# Altium schematic sheet Microphone-Arm_V1.0.SchDoc
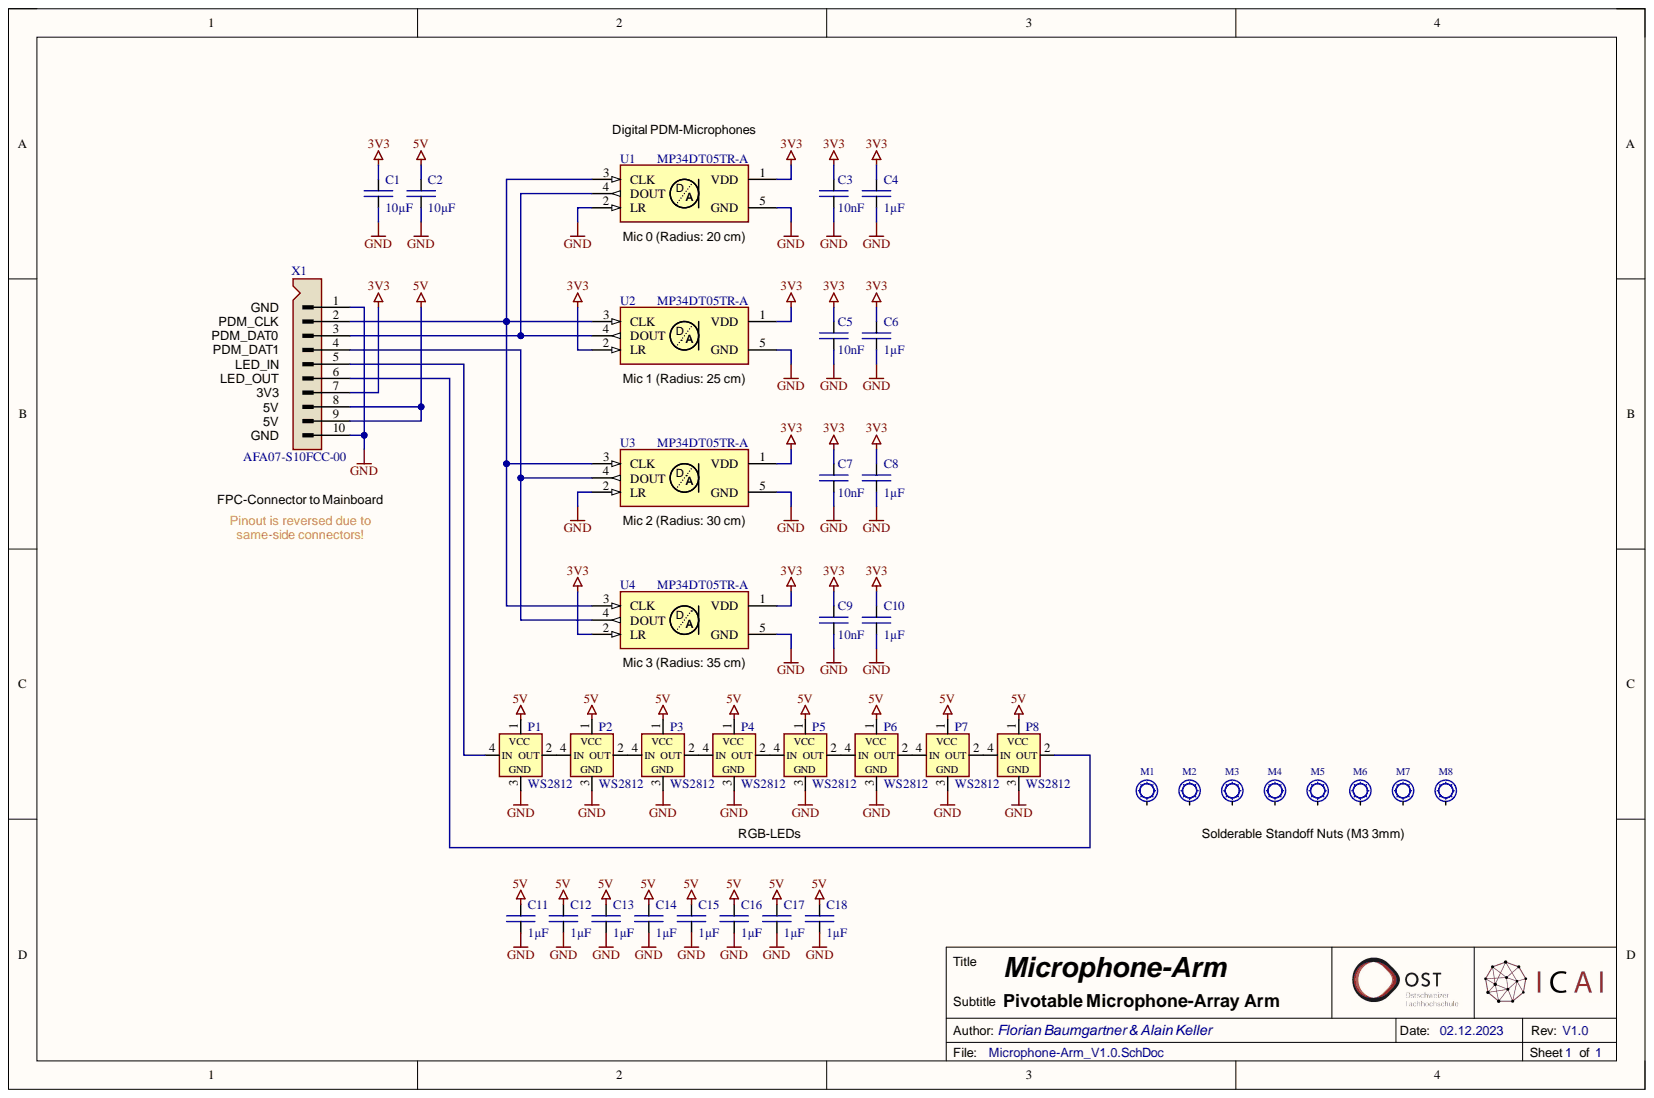
[diagram p0: Microphone-Arm_V1.0.SchDoc]

## Parts
C1  10µF  [SMD Keramikkondensator 1206]
C2  10µF  [SMD Keramikkondensator 1206]
C3  10nF  [SMD Keramikkondensator 0603]
C4  1µF  [SMD Keramikkondensator 0603]
C5  10nF  [SMD Keramikkondensator 0603]
C6  1µF  [SMD Keramikkondensator 0603]
C7  10nF  [SMD Keramikkondensator 0603]
C8  1µF  [SMD Keramikkondensator 0603]
C9  10nF  [SMD Keramikkondensator 0603]
C10  1µF  [SMD Keramikkondensator 0603]
C11  1µF  [SMD Keramikkondensator 0603]
C12  1µF  [SMD Keramikkondensator 0603]
C13  1µF  [SMD Keramikkondensator 0603]
C14  1µF  [SMD Keramikkondensator 0603]
C15  1µF  [SMD Keramikkondensator 0603]
C16  1µF  [SMD Keramikkondensator 0603]
C17  1µF  [SMD Keramikkondensator 0603]
C18  1µF  [SMD Keramikkondensator 0603]
M1  SMTSO-M3-3ET  [SMD Solder-Nut (M3 3mm)]  (value not drawn)
M2  SMTSO-M3-3ET  [SMD Solder-Nut (M3 3mm)]  (value not drawn)
M3  SMTSO-M3-3ET  [SMD Solder-Nut (M3 3mm)]  (value not drawn)
M4  SMTSO-M3-3ET  [SMD Solder-Nut (M3 3mm)]  (value not drawn)
M5  SMTSO-M3-3ET  [SMD Solder-Nut (M3 3mm)]  (value not drawn)
M6  SMTSO-M3-3ET  [SMD Solder-Nut (M3 3mm)]  (value not drawn)
M7  SMTSO-M3-3ET  [SMD Solder-Nut (M3 3mm)]  (value not drawn)
M8  SMTSO-M3-3ET  [SMD Solder-Nut (M3 3mm)]  (value not drawn)
P1  WS2812  [SMD LED WS2812B-0807]
P2  WS2812  [SMD LED WS2812B-0807]
P3  WS2812  [SMD LED WS2812B-0807]
P4  WS2812  [SMD LED WS2812B-0807]
P5  WS2812  [SMD LED WS2812B-0807]
P6  WS2812  [SMD LED WS2812B-0807]
P7  WS2812  [SMD LED WS2812B-0807]
P8  WS2812  [SMD LED WS2812B-0807]
U1  MP34DT05TR-A  [SMD Microphone (MP34DT05TR-A)]
U2  MP34DT05TR-A  [SMD Microphone (MP34DT05TR-A)]
U3  MP34DT05TR-A  [SMD Microphone (MP34DT05TR-A)]
U4  MP34DT05TR-A  [SMD Microphone (MP34DT05TR-A)]
X1  AFA07-S10FCC-00  [SMD Connector (AFA07-S10FCC-00)]

## Nets
3V3: C1.2 C3.2 C4.2 C5.2 C6.2 C7.2 C8.2 C9.2 C10.2 U1.1(VDD) U2.1(VDD) U2.2(LR) U3.1(VDD) U4.1(VDD) U4.2(LR) X1.7
5V: C2.2 C11.2 C12.2 C13.2 C14.2 C15.2 C16.2 C17.2 C18.2 P1.1 P2.1 P3.1 P4.1 P5.1 P6.1 P7.1 P8.1 X1.8 X1.9
GND: C1.1 C2.1 C3.1 C4.1 C5.1 C6.1 C7.1 C8.1 C9.1 C10.1 C11.1 C12.1 C13.1 C14.1 C15.1 C16.1 C17.1 C18.1 P1.3 P2.3 P3.3 P4.3 P5.3 P6.3 P7.3 P8.3 U1.2(LR) U1.5(GND) U2.5(GND) U3.2(LR) U3.5(GND) U4.5(GND) X1.1 X1.10
NetP1_2: P1.2(OUT) P2.4(IN)
NetP1_4: P1.4(IN) X1.5
NetP2_2: P2.2(OUT) P3.4(IN)
NetP3_2: P3.2(OUT) P4.4(IN)
NetP4_2: P4.2(OUT) P5.4(IN)
NetP5_2: P5.2(OUT) P6.4(IN)
NetP6_2: P6.2(OUT) P7.4(IN)
NetP7_2: P7.2(OUT) P8.4(IN)
NetP8_2: P8.2(OUT) X1.6
NetU1_3: U1.3(CLK) U2.3(CLK) U3.3(CLK) U4.3(CLK) X1.2
NetU1_4: U1.4(DOUT) U2.4(DOUT) X1.3
NetU3_4: U3.4(DOUT) U4.4(DOUT) X1.4

Not connected: M1 1; M2 1; M3 1; M4 1; M5 1; M6 1; M7 1; M8 1

## Library data of the parts
C1 C2 = E6 - 10uF: SMD Ceramic Capacitor E6; Component Description: Multilayer Ceramic Capacitors MLCC; Component Value: 10µF 50V; Component Kind: Standard; ComponentLink1Description: Datasheet; ComponentLink1URL: https://datasheet.lcsc.com/lcsc/1810191219_Samsung-Electro-Mechanics-CL10A106KP8NNNC_C19702.pdf; Digikey: 587-3258-1-ND; Farnell: 2113070; JLC-Parts: C13585; Library Name: Ceramic Capacitors-E6.SchLib; Mouser: 963-LMK107BBJ106MALT; Unit Price: 0.01
C3 C5 C7 C9 = C-CAPACITOR: CAP-MK; Component Description: Multilayer Ceramic Capacitors; Component Value: 10nF 50V; Component Kind: Standard; Digikey: 445-5662-1-ND; Farnell: 2346966; JLC-Parts: C57112; Library Name: AudioAmp.SCHLIB; Mouser: 77-VJ0603V103ZXAPBC; Unit Price: 0.01
C4 C6 C8 C10-C18 = C-CAPACITOR: CAP-MK; Component Description: Multilayer Ceramic Capacitors; Component Value: 1µF 50V; Component Kind: Standard; Digikey: 1276-1860-1-ND; Farnell: 3013383; JLC-Parts: C15849; Library Name: AudioAmp.SCHLIB; Mouser: 187-CL10A105KB8NNNC; Unit Price: 0.01
M1-M8 = M-Spacer_M3_3mm: Solderable Standoff Nut; Component Value: M3 3mm; Component Description: Solderable Standoff Nut; Component Kind: Standard; ComponentLink1Description: Datasheet; ComponentLink1URL: https://datasheet.lcsc.com/lcsc/2112061830_Sinhoo-SMTSO3030CTJ_C2915308.pdf; JLC-Parts: C2915308; Mouser: 153-SMTSO-M3-3ET; Unit Price: 0.05; Library Name: Velotour_2022.SCHLIB
P1-P8 = P-WS2812B-0807: Smart RGB-LED; aliExpress: 1005003999865721; Component Value: XL-0807RGBC-WS2812B; Component Description: Smart RGB-LED; Component Kind: Standard; ComponentLink1Description: Datasheet; ComponentLink1URL: https://datasheet.lcsc.com/lcsc/2108251530_OPSCO-Optoelectronics-SK6812D-EC3210R_C2890041.pdf; JLC-Parts: C3646929; Unit Price: 0.07; Library Name: Acquisition-System.SCHLIB
U1-U4 = U-MP34DT05TR-A: Digital PDM MEMS Microphone (Top Port); Component Description: Digital PDM MEMS Microphone (Top Port); Component Kind: Standard; Component Value: MP34DT05TR-A; ComponentLink1Description: Datasheet; ComponentLink1URL: https://datasheet.lcsc.com/lcsc/2106070037_STMicroelectronics-MP34DT05TR-A_C2688664.pdf; Digikey: 497-17809-1-ND; Farnell: 2851942; JLC-Parts: C2688664; Library Name: Microphone-Boards.SCHLIB; Mouser: 511-MP34DT05TR-A; Unit Price: 1.50
X1 = X-FPC-Connector (10-Pin): FPC-Connector (10-Pin, Slide-Lock,  Bottom Contact); Component Description: FPC-Connector (10-Pin, Slide-Lock,  Bottom Contact); Component Kind: Standard; Component Value: AFA07-S10FCC-00; ComponentLink1Description: Datasheet; ComponentLink1URL: https://datasheet.lcsc.com/lcsc/1811142212_JUSHUO-AFA07-S10FCC-00_C52162.pdf; Digikey: 2073-FFC3B07-10-TCT-ND; Farnell: 3873345; JLC-Parts: C52162; Library Name: Mainboard.SCHLIB; Mouser: 640-FFC3B07-10-T; Unit Price: 0.09
